# Altium schematic sheet Sheet1.SchDoc(Sheet1)
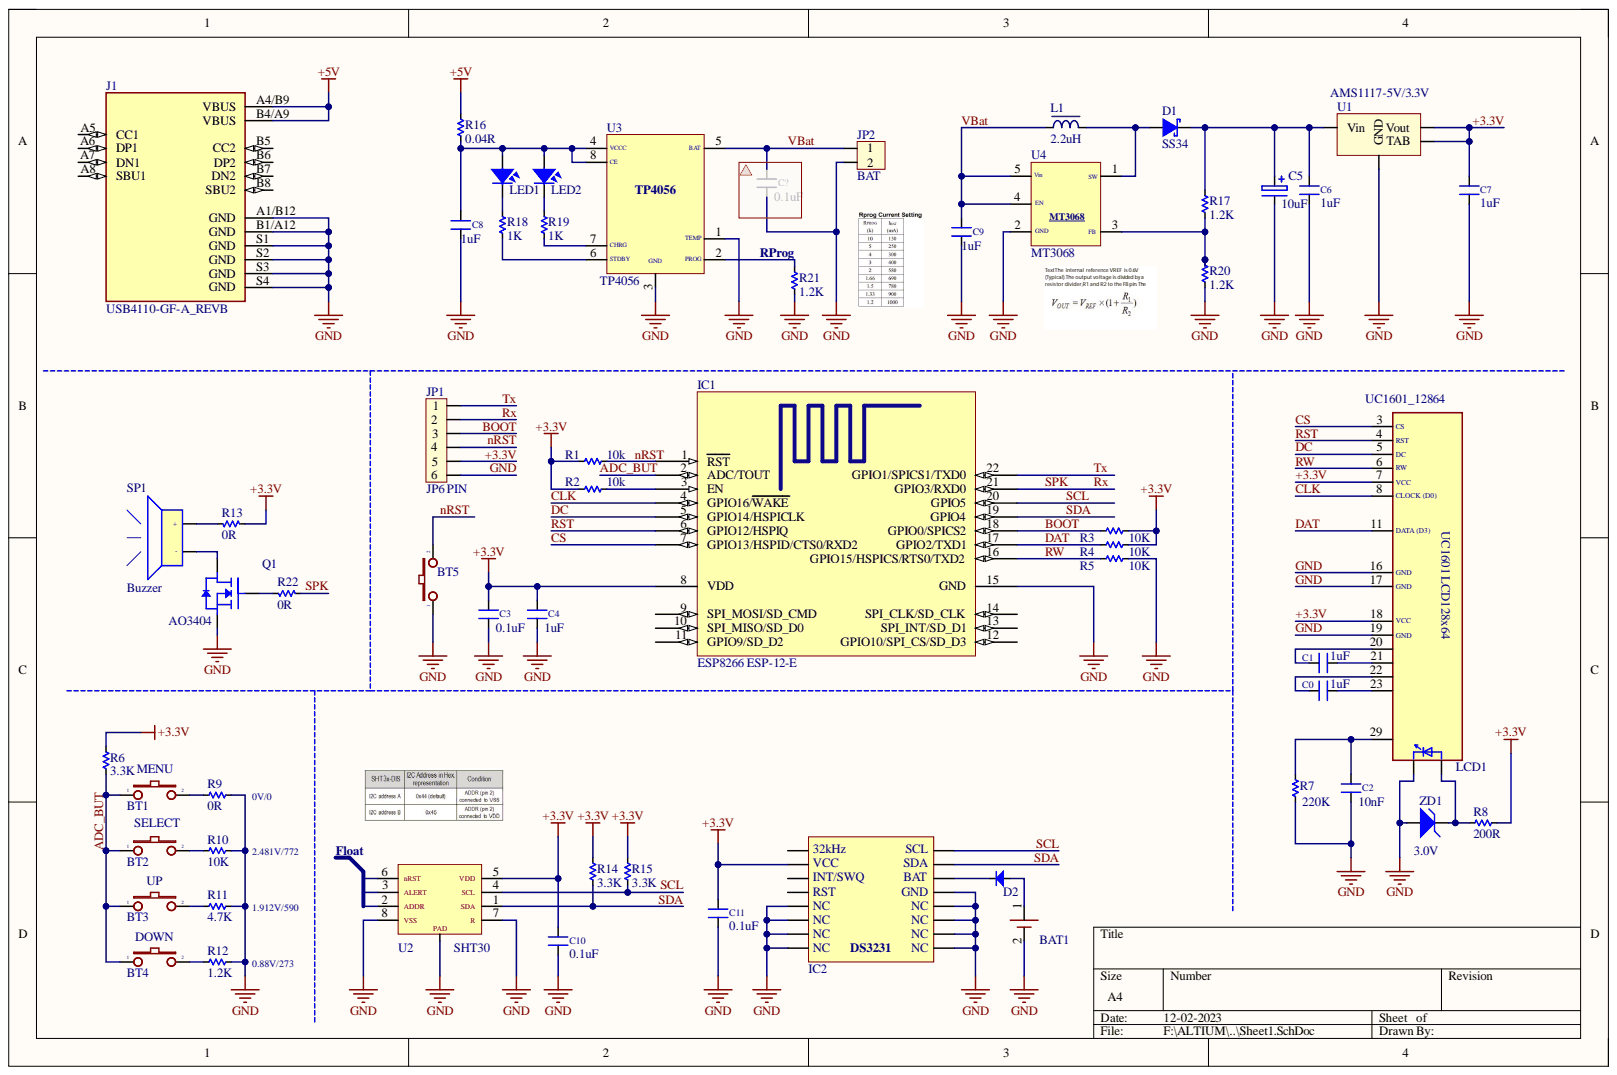
[diagram p0: Sheet1.SchDoc]

## Parts
BAT1  CR2032  [CR2032_SMD]  (value not drawn)
BT1  BUTTON1  [SW4]  (value not drawn)
BT2  BUTTON1  [SW4]  (value not drawn)
BT3  BUTTON1  [SW4]  (value not drawn)
BT4  BUTTON1  [SW4]  (value not drawn)
BT5  BUTTON1  [SW4_SMD]  (value not drawn)
C0  1uF  [CN-SMD-0805]
C1  1uF  [CN-SMD-0805]
C2  10nF  [CN-SMD-0805]
C3  0.1uF  [CN-SMD-0805]
C4  1uF  [CN-SMD-0805]
C5  10uF  [cap_A_SMD 10uF/16V]
C6  1uF  [CN-SMD-0805]
C7  1uF  [CN-SMD-0805]
C8  1uF  [CN-SMD-0805]
C9  1uF  [CN-SMD-0805]
C10  0.1uF  [CN-SMD-0805]
C11  0.1uF  [CN-SMD-0805]
D1  SS34  [DO-214AC(SMA)]
D2  (no value)  [SOD123]
IC1  ESP8266 ESP-12-E  [ESP8266 ESP-12-E]
IC2  DS3231  [SOIC-16]
J1  USB4110-GF-A_REVB  [GCT_USB4105-GF-A]
JP1  JP6 PIN  [JP6]
JP2  BAT  [CON2]
L1  2.2uH  [L-SMD-06030-7.4x6.6X3]
LCD1  UC1601_12864  [UC1601_LCD]
LED1  GREEN  [SM-LED-0805-Green]  (value not drawn)
LED2  RED  [SM-LED-0805-Red]  (value not drawn)
Q1  AO3404  [SOT23-3]
R1  10k  [RES-SMD-0805]
R2  10k  [RES-SMD-0805]
R3  10K  [RES-SMD-0805]
R4  10K  [RES-SMD-0805]
R5  10K  [RES-SMD-0805]
R6  3.3K  [RES-SMD-0805]
R7  220K  [RES-SMD-0805]
R8  200R  [RES-SMD-1206]
R9  0R  [RES-SMD-0805]
R10  10K  [RES-SMD-0805]
R11  4.7K  [RES-SMD-0805]
R12  1.2K  [RES-SMD-0805]
R13  0R  [RES-SMD-0805]
R14  3.3K  [RES-SMD-0805]
R15  3.3K  [RES-SMD-0805]
R16  0.04R  [RES-SMD-1206]
R17  1.2K  [RES-SMD-0805]
R18  1K  [RES-SMD-0805]
R19  1K  [RES-SMD-0805]
R20  1.2K  [RES-SMD-0805]
R21  1.2K  [RES-SMD-0805]
R22  0R  [RES-SMD-0805]
SP1  Buzzer  [Buzzer]
U1  AMS1117-5V/3.3V  [SOT-223]
U2  SHT30  [SHT-30]
U3  TP4056  [SOP-8]
U4  MT3068  [SOT23-6]
ZD1  3.0V  [SOD80, LL-34]

## Nets
+5V: J1.A4/B9(VBUS) J1.B4/A9(VBUS) R16.1
+3.3V: C3.2 C4.2 C7.2 C10.2 C11.2 IC1.8(VDD) IC2.2(VCC) JP1.5 LCD1.7(VCC) LCD1.18(VCC) R1.2 R2.2 R3.1 R4.1 R6.1 R8.1 R13.1 R14.1 R15.1 U1.2 U2.5(VDD)
ADC_BUT: BT1.1 BT2.1 BT3.1 BT4.1 IC1.2(ADC/TOUT) R6.2
BOOT: IC1.18(GPIO0/SPICS2) JP1.3 R3.2
CLK: IC1.4(GPIO16/WAKE) LCD1.8(CLOCK_(D0))
CS: IC1.7(GPIO13/HSPID/CTS0/RXD2) LCD1.3(CS)
DAT: IC1.17(GPIO2/TXD1) LCD1.11(DATA_(D3)) R4.2
DC: IC1.5(GPIO14/HSPICLK) LCD1.5(DC)
GND: BAT1.2 BT5.1 C2.1 C3.1 C4.1 C5.2 C6.1 C7.1 C8.1 C9.1 C10.1 C11.1 IC1.15(GND) IC2.5(NC) IC2.6(NC) IC2.7(NC) IC2.8(NC) IC2.9(NC) IC2.10(NC) IC2.11(NC) IC2.12(NC) IC2.13(GND) J1.A1/B12(GND) J1.B1/A12(GND) J1.S1(GND) J1.S2(GND) J1.S3(GND) J1.S4(GND) JP1.6 JP2.2 LCD1.16(GND) LCD1.17(GND) LCD1.19(GND) LCD1.K Q1.3 R5.1 R7.2 R9.2 R10.2 R11.2 R12.2 R20.2 R21.2 U1.1 U2.7(R) U2.8(VSS) U2.PAD U3.1(TEMP) U3.3 U4.2(GND) ZD1.1
nRST: BT5.2 IC1.1(RST) JP1.4 R1.1
RST: IC1.6(GPIO12/HSPIQ) LCD1.4(RST)
RW: IC1.16(GPIO15/HSPICS/RTS0/TXD2) LCD1.6(RW) R5.2
Rx: IC1.21(GPIO3/RXD0) JP1.2 R22.1
SCL: IC1.20(GPIO5) IC2.16(SCL) R15.2 U2.4(SCL)
SDA: IC1.19(GPIO4) IC2.15(SDA) R14.2 U2.1(SDA)
Tx: IC1.22(GPIO1/SPICS1/TXD0) JP1.1
VBat: C9.2 JP2.1 L1.1 U3.5(BAT) U4.4(EN) U4.5(Vin)
NetBAT1_1: BAT1.1 D2.A
NetBT1_2: BT1.2 R9.1
NetBT2_2: BT2.2 R10.1
NetBT3_2: BT3.2 R11.1
NetBT4_2: BT4.2 R12.1
NetC0_1: C0.1 LCD1.22
NetC0_2: C0.2 LCD1.23
NetC1_1: C1.1 LCD1.20
NetC1_2: C1.2 LCD1.21
NetC2_2: C2.2 LCD1.29 R7.1
NetC5_1: C5.1 C6.2 D1.K R17.1 U1.3
NetC8_2: C8.2 LED1.1 LED2.1 R16.2 U3.4(VCCC) U3.8(CE)
NetD1_A: D1.A L1.2 U4.1(SW)
NetD2_K: D2.K IC2.14(BAT)
NetIC1_3: IC1.3(EN) R2.1
NetLCD1_A: LCD1.A R8.2 ZD1.2
NetLED1_2: LED1.2 R18.1
NetLED2_2: LED2.2 R19.1
NetQ1_1: Q1.1 R22.2
NetQ1_2: Q1.2 SP1.2
NetR13_2: R13.2 SP1.1
NetR17_2: R17.2 R20.1 U4.3(FB)
NetR18_2: R18.2 U3.6(STDBY)
NetR19_2: R19.2 U3.7(CHRG)
NetR21_1: R21.1 U3.2(PROG)

Not connected: IC1 9(SPI_MOSI/SD_CMD) 10(SPI_MISO/SD_D0) 11(GPIO9/SD_D2) 12(GPIO10/SPI_CS/SD_D3) 13(SPI_INT/SD_D1) 14(SPI_CLK/SD_CLK); IC2 1(32kHz) 3(INT/SWQ) 4(RST); J1 A5(CC1) A6(DP1) A7(DN1) A8(SBU1) B5(CC2) B6(DP2) B7(DN2) B8(SBU2); U2 2(ADDR) 3(ALERT) 6(nRST)

## Library data of the parts
BT1-BT5 = BUTTON1: Value: 1N4007; Component Kind: Standard; Description: BUTTON1; Library Name: Sang_Library.IntLib
C0-C4 C6-C11 = CAP SMD: Designed by: VanDungEvn; Value: 0,1uF/300V; Component Kind: Standard; Description: CAP SMD; Library Name: Sang_Library.IntLib
C5 = CAP_Radial1: Component Kind: Standard; Description: CAP_Radial1; Library Name: Sang_Library.IntLib
D1 = Diode  Schottky: Value: 1N4007; Component Kind: Standard; Description: Diode  Schottky; Library Name: Sang_Library.IntLib
D2 = Diode-1N4007 -A4: Default Diode; Code - JEDEC: DO-214-AB; Value: 1N5007; Component Kind: Standard; Published: 8-Jun-2000; Revision: July-2002: Re-released for DXP Platform.; Library Name: Sang_Library.IntLib; Publisher: Altium Limited
J1 = USB4110-GF-A_REVB: USB-C (USB TYPE-C) USB 2.0 Receptacle Connector 24 (16+8 Dummy) Position Surface Mount, Right Angle; Through Hole; MF: GCT; Availability: Warning; Check_prices: https://www.snapeda.com/parts/USB4110-GF-A/Global%20Connector%20Technology/view-part/4001167/?ref=eda; Component Kind: Standard; MP: USB4110-GF-A; Package: None; Price: None; Purchase-URL: https://pricing.snapeda.com/search/part/USB4110-GF-A/?ref=eda; SnapEDA_Link: https://www.snapeda.com/parts/USB4110-GF-A/Global%20Connector%20Technology/view-part/4001167/?ref=snap; Library Name: Sang_Library.IntLib
JP1 = JP6: Value: JP7; Component Kind: Standard; Description: JP6 PIN; Library Name: Sang_Library.IntLib
JP2 = Con2: Connector 2; Component Kind: Standard; Value: Connector 2; Library Name: KhanhBK_Library_4.IntLib
L1 = inductor-SMD: Value: 150 mH; Component Kind: Standard; Description: inductor-SMD; Library Name: Sang_Library.IntLib
LED1 LED2 = LED-SMD-0805: Component Kind: Standard; Library Name: Sang_Library.IntLib
R1-R22 = Res-SMD: Resistor; Manufacturer: Generic Components; Class: Passive; Component Kind: Standard; Published: 08/06/2000; Library Name: Sang_Library.IntLib; Publisher: Altium Hobart Technology Centre
SP1 = Buzzer_5V: Component Kind: Standard; Library Name: Huan.IntLib
U1 = AMS1117-5V/3.3V: IC on ap 5V/3.3V; Component Kind: Standard; Library Name: Sang_Library.IntLib
U2 = SHT-30: Component Kind: Standard; Library Name: Huan.IntLib
ZD1 = Diode Zener: Zener Diode; Component Kind: Standard; LatestRevisionDate: 17-Jul-2002; LatestRevisionNote: Re-released for DXP Platform.; PackageReference: DIODE-0.7; Published: 8-Jun-2000; Library Name: Sang_Library.IntLib; Publisher: Altium Limited
BAT1 = CR2032: Component Kind: Standard; Library Name: Huan.SchLib
IC1 = ESP8266 ESP-12-E: Component Kind: Standard; Description: ESP8266 ESP-12-E; Library Name: KhanhBK_Library_4.IntLib
IC2 = DS3231: Component Kind: Standard; Library Name: Huan.SchLib
LCD1 = UC1601_12864: Component Kind: Standard; Library Name: Huan.IntLib
Q1 = AO3404: Component Kind: Standard; Library Name: Huan.IntLib
U3 = TP4056: Component Kind: Standard; Library Name: Huan.SchLib
U4 = MT3068: Component Kind: Standard; Library Name: Huan.SchLib
